# Altium schematic sheet ICOPYX_PM3_ANT_V1.4.SchDoc
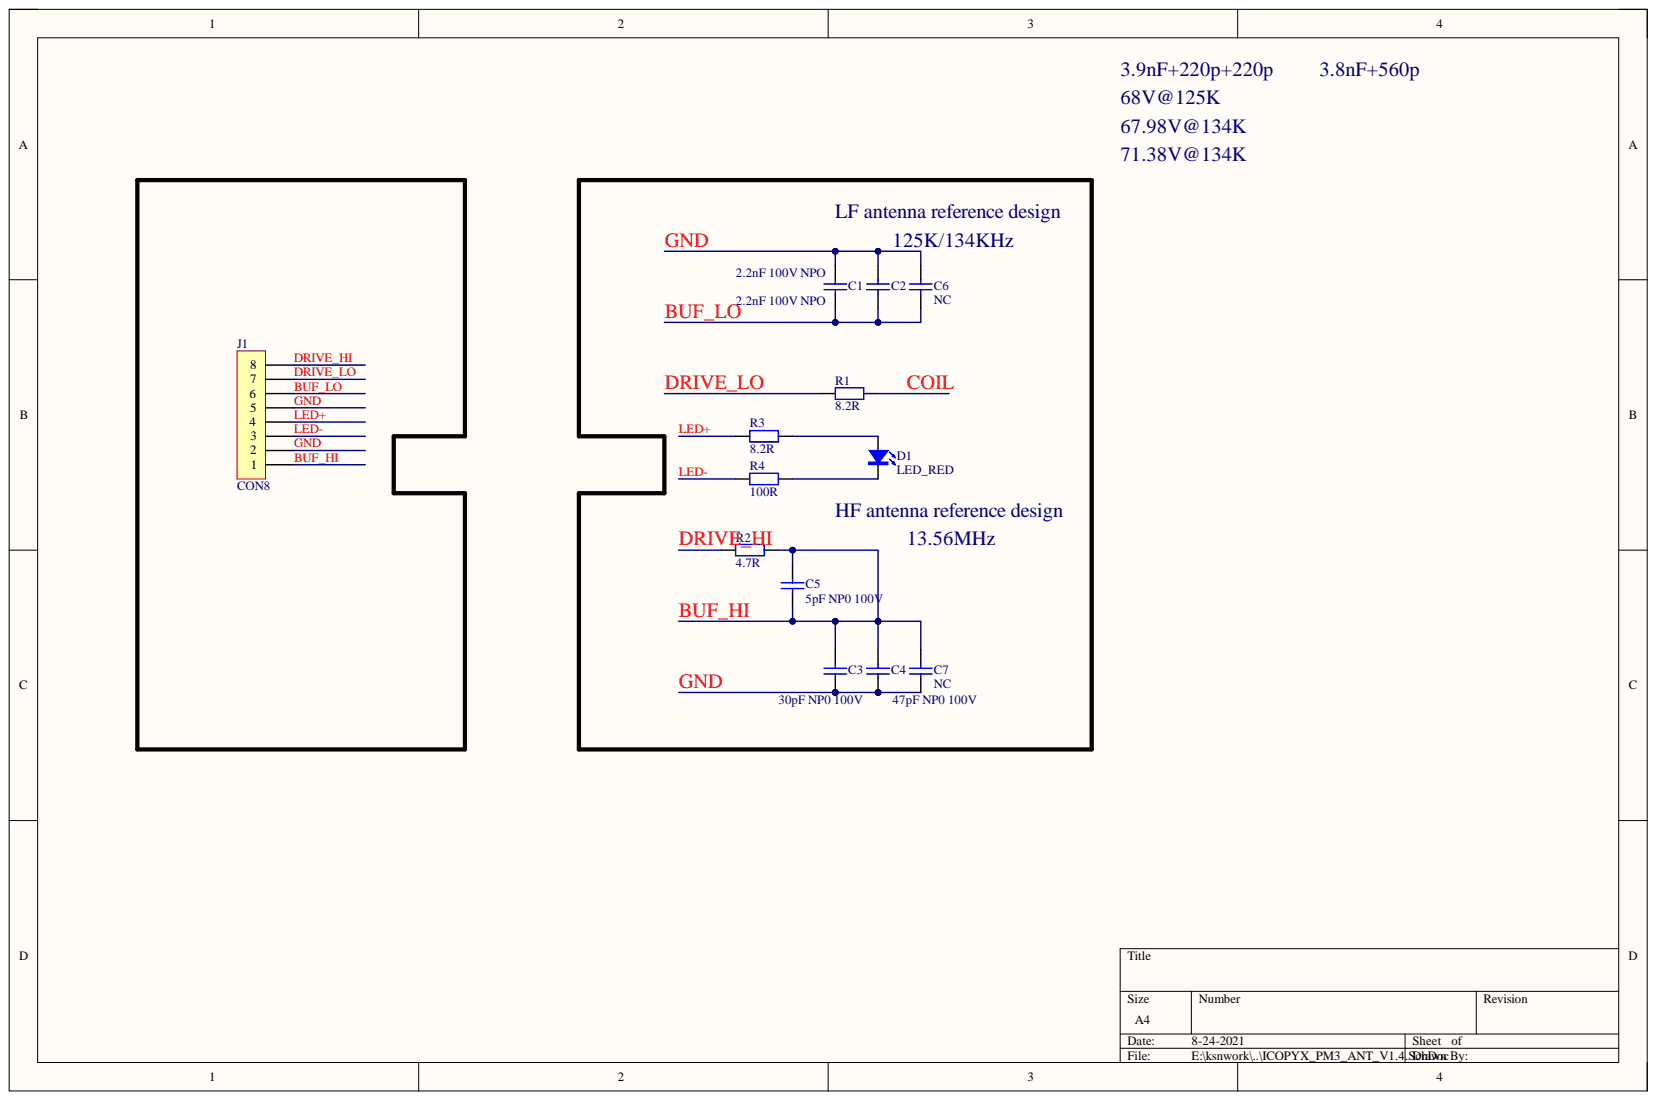
[diagram p0: ICOPYX_PM3_ANT_V1.4.SchDoc]

## Parts
C1  2.2nF 100V NPO  [0805_CAP]
C2  2.2nF 100V NPO  [0805_CAP]
C3  30pF NP0 100V  [0805_CAP]
C4  47pF NP0 100V  [0805_CAP]
C5  5pF NP0 100V  [0805_CAP]
C6  NC  [0805_CAP]
C7  NC  [0805_CAP]
D1  LED_RED  [LED_LSA67K_4040侧发光_红色]
J1  CON8  [FPC_CON8_翻盖镀金维迅]
R1  8.2R  [1206_RES]
R2  4.7R  [0603_RES2]
R3  8.2R  [1206_RES]
R4  100R  [1206_RES]

## Nets
BUF_HI: C3.2 C4.2 C5.1 C5.2 C7.1 J1.1 R2.2
BUF_LO: C1.2 C2.2 C6.2 J1.6
COIL: R1.1
DRIVE_HI: J1.8 R2.1
DRIVE_LO: J1.7 R1.2
GND: C1.1 C2.1 C3.1 C4.1 C6.1 C7.2 J1.2 J1.5
LED+: J1.4 R3.2
LED-: J1.3 R4.2
NetD1_1: D1.1 R3.1
NetD1_2: D1.2 R4.1

## Library data of the parts
C1-C7 = CAP_1: Component Kind: Standard; Library Name: MD_分立元件自定义标准库.SchLib
D1 = LED_2: Component Kind: Standard; Library Name: MD_分立元件自定义标准库.SchLib
J1 = CON8: Connector; Component Kind: Standard; Library Name: 杂元件库.SCHLIB
R1-R4 = RES_MD: Component Kind: Standard; Library Name: MD_分立元件自定义标准库.SchLib
